# Altium schematic sheet DC_DC_5V_1.SchDoc
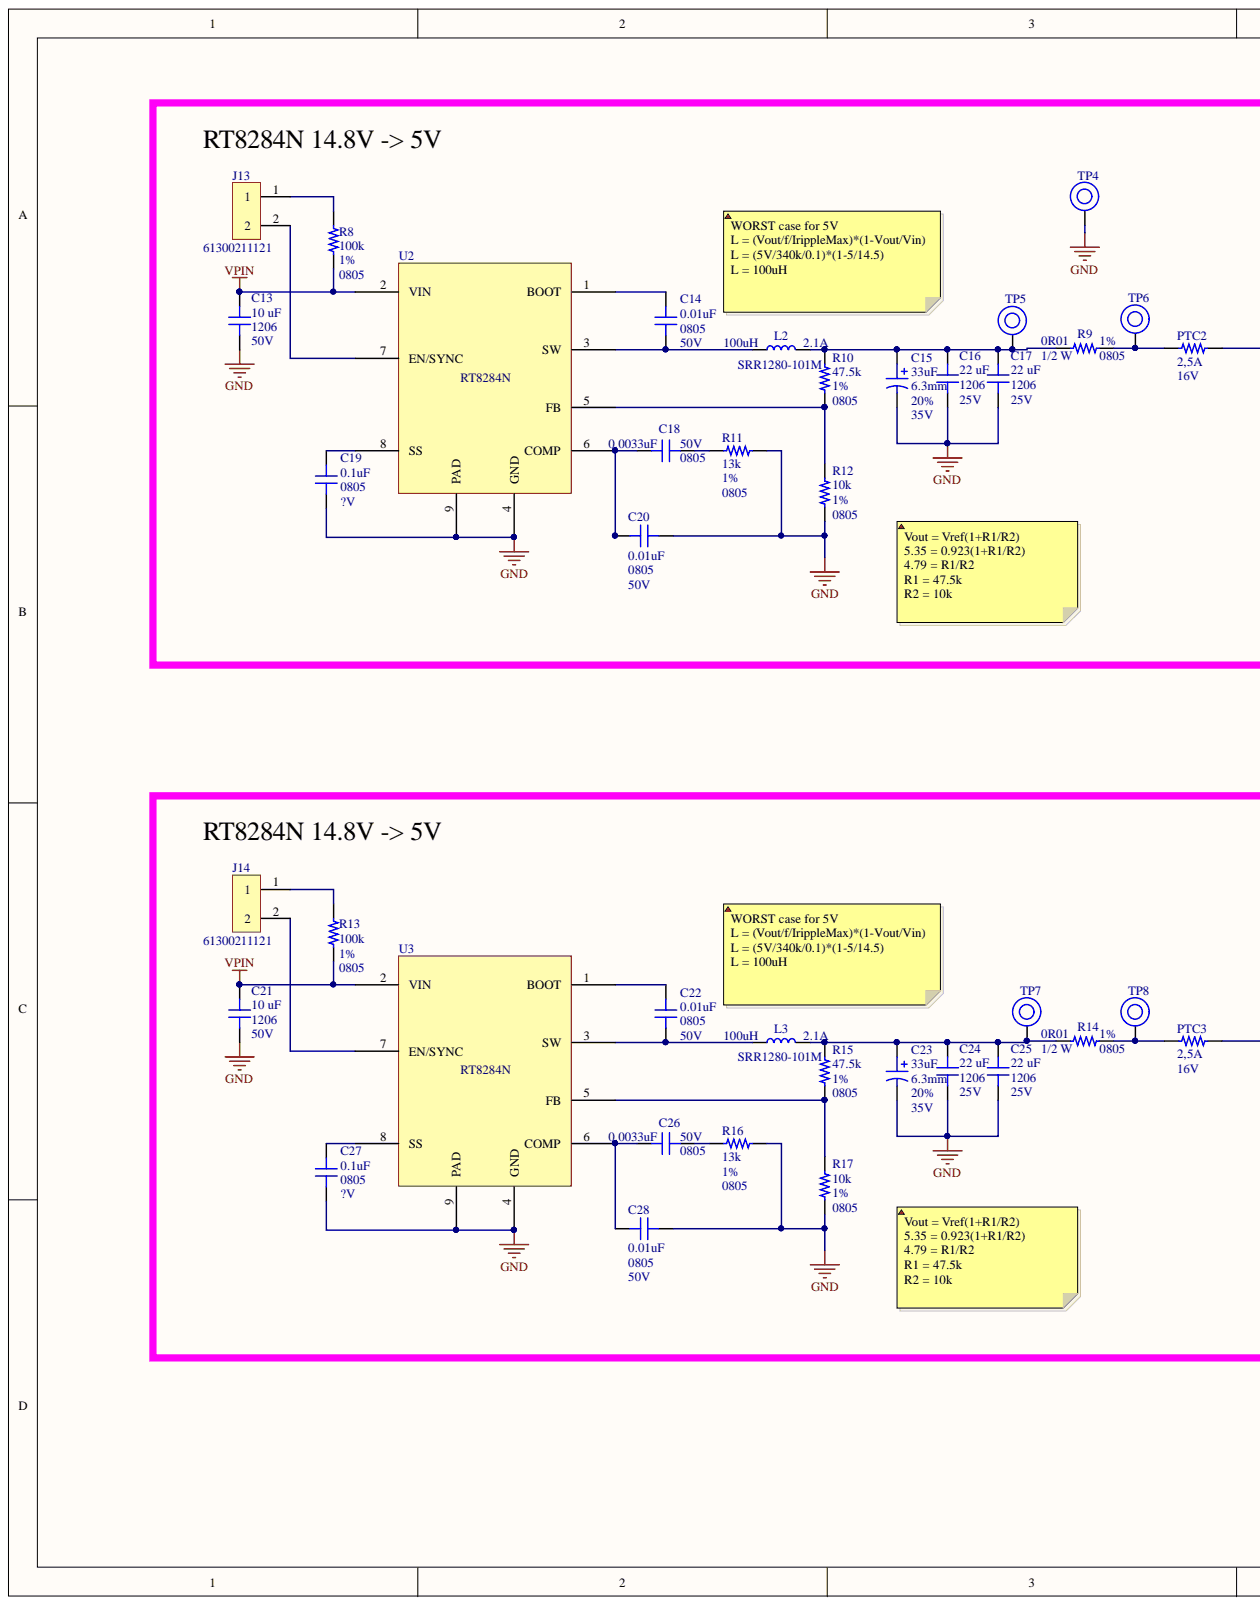
[diagram p0: DC_DC_5V_1.SchDoc, left]
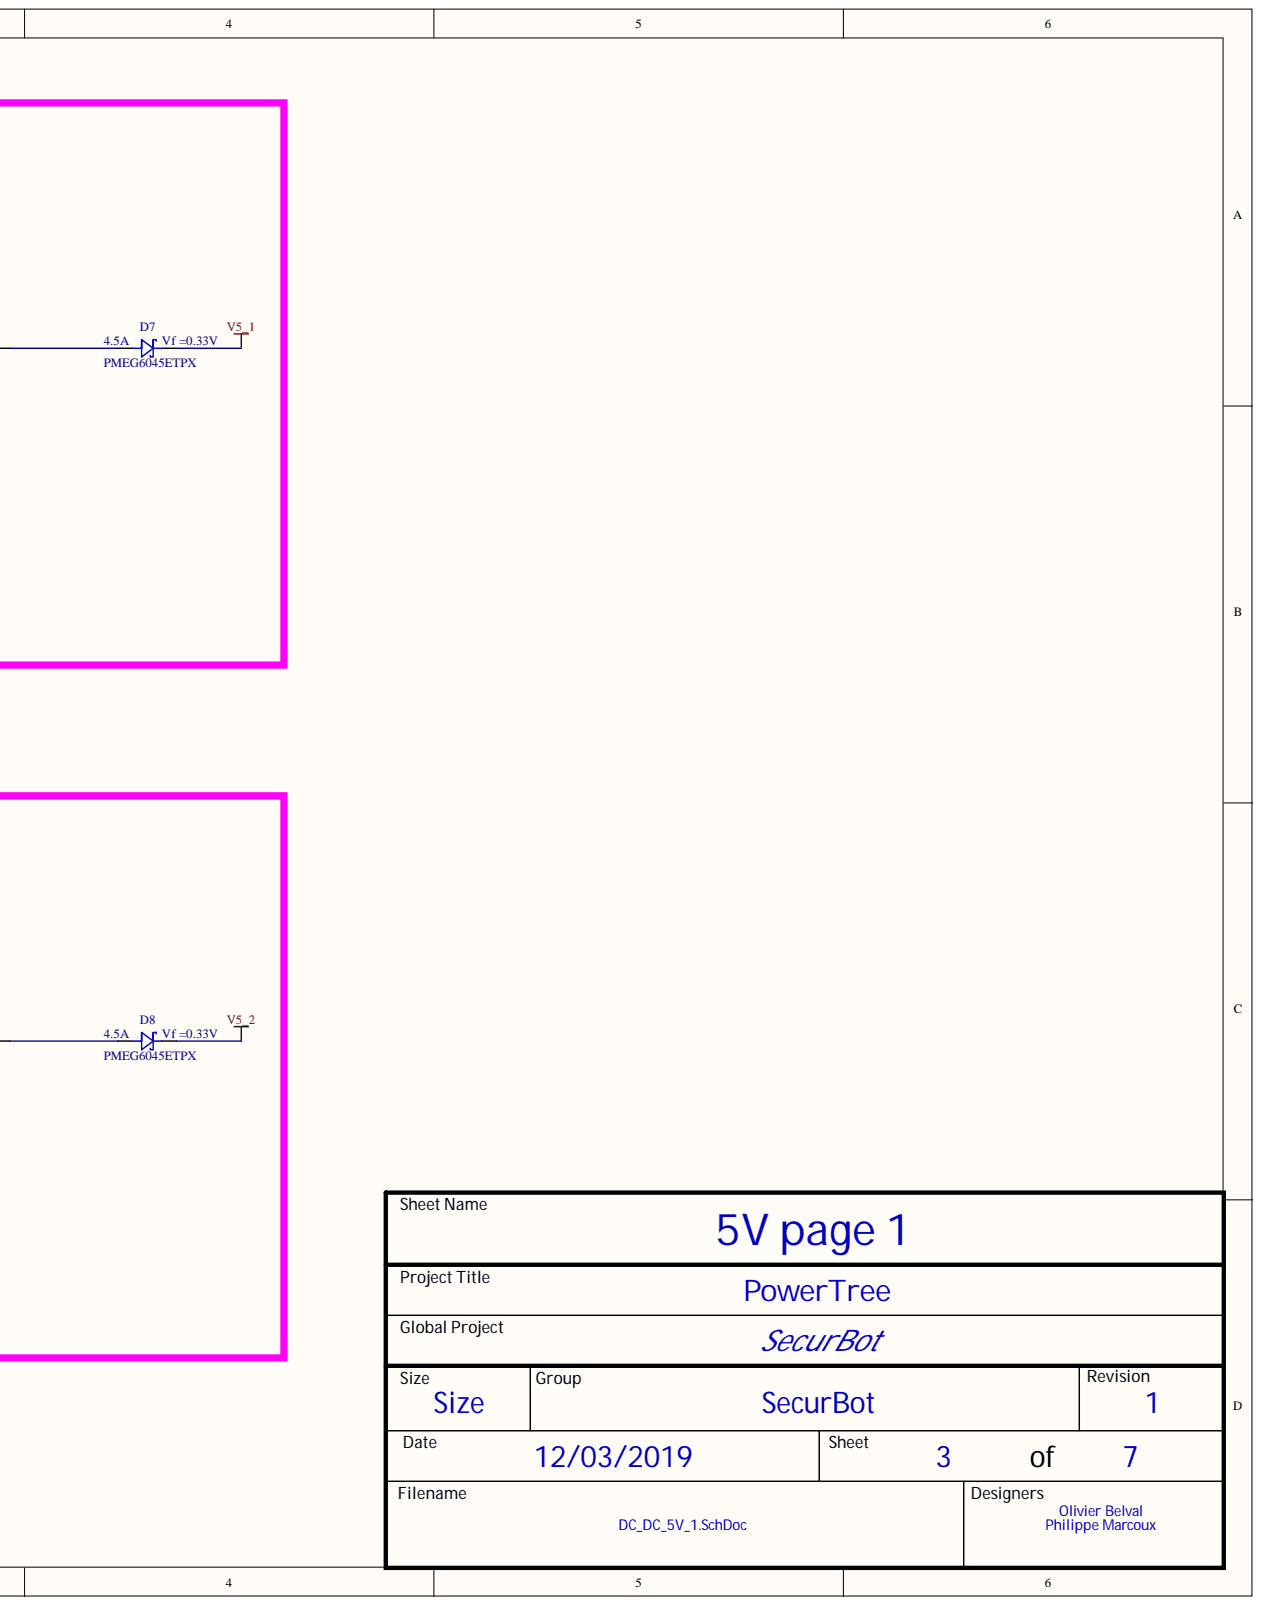
[diagram p1: DC_DC_5V_1.SchDoc, right]

## Parts
C13  value 10 uF  Package: 1206  Voltage: 50V  [CAP_1206]  (hidden comment: CAP - 1206)
C14  value 0.01uF  Package: 0805  Voltage: 50V  [CAP_0805]  (hidden comment: CAP - 0805)
C15  value 33uF  Package: 6.3mm  Tolerance: 20%  Voltage: 35V  [CAP ALU - 6.3mm DIA]  (hidden comment: CAP ALU - 6.3mm)
C16  value 22 uF  Package: 1206  Voltage: 25V  [CAP_1206]  (hidden comment: CAP - 1206)
C17  value 22 uF  Package: 1206  Voltage: 25V  [CAP_1206]  (hidden comment: CAP - 1206)
C18  value 0.0033uF  Package: 0805  Voltage: 50V  [CAP_0805]  (hidden comment: CAP - 0805)
C19  value 0.1uF  Package: 0805  Voltage: ?V  [CAP_0805]  (hidden comment: CAP - 0805)
C20  value 0.01uF  Package: 0805  Voltage: 50V  [CAP_0805]  (hidden comment: CAP - 0805)
C21  value 10 uF  Package: 1206  Voltage: 50V  [CAP_1206]  (hidden comment: CAP - 1206)
C22  value 0.01uF  Package: 0805  Voltage: 50V  [CAP_0805]  (hidden comment: CAP - 0805)
C23  value 33uF  Package: 6.3mm  Tolerance: 20%  Voltage: 35V  [CAP ALU - 6.3mm DIA]  (hidden comment: CAP ALU - 6.3mm)
C24  value 22 uF  Package: 1206  Voltage: 25V  [CAP_1206]  (hidden comment: CAP - 1206)
C25  value 22 uF  Package: 1206  Voltage: 25V  [CAP_1206]  (hidden comment: CAP - 1206)
C26  value 0.0033uF  Package: 0805  Voltage: 50V  [CAP_0805]  (hidden comment: CAP - 0805)
C27  value 0.1uF  Package: 0805  Voltage: ?V  [CAP_0805]  (hidden comment: CAP - 0805)
C28  value 0.01uF  Package: 0805  Voltage: 50V  [CAP_0805]  (hidden comment: CAP - 0805)
D7  Current: 4.5A  Part number: PMEG6045ETPX  Vf: Vf =0.33V  [DO-214AC_SOD128]  (hidden comment: SCHOTTKEY)
D8  Current: 4.5A  Part number: PMEG6045ETPX  Vf: Vf =0.33V  [DO-214AC_SOD128]  (hidden comment: SCHOTTKEY)
J13  Part number: 61300211121  [HDR1X2]  (hidden comment: Header 2 pin SM)
J14  Part number: 61300211121  [HDR1X2]  (hidden comment: Header 2 pin SM)
L2  value 100uH  Current: 2.1A  Part number: SRR1280-101M  [BOURNS 12.5x12.5mm]  (hidden comment: IND)
L3  value 100uH  Current: 2.1A  Part number: SRR1280-101M  [BOURNS 12.5x12.5mm]  (hidden comment: IND)
PTC2  Trip value: 2,5A  Voltage: 16V  [PTC - 1812]  (hidden comment: PTC - 1812)
PTC3  Trip value: 2,5A  Voltage: 16V  [PTC - 1812]  (hidden comment: PTC - 1812)
R8  value 100k  Package: 0805  Tolerance: 1%  [FER_0805]  (hidden comment: RES - 0805)
R9  value 0R01  Package: 0805  Tolerance: 1%  Watt: 1/2 W  [FER_0805]  (hidden comment: RES - 0805)
R10  value 47.5k  Package: 0805  Tolerance: 1%  [FER_0805]  (hidden comment: RES - 0805)
R11  value 13k  Package: 0805  Tolerance: 1%  [FER_0805]  (hidden comment: RES - 0805)
R12  value 10k  Package: 0805  Tolerance: 1%  [FER_0805]  (hidden comment: RES - 0805)
R13  value 100k  Package: 0805  Tolerance: 1%  [FER_0805]  (hidden comment: RES - 0805)
R14  value 0R01  Package: 0805  Tolerance: 1%  Watt: 1/2 W  [FER_0805]  (hidden comment: RES - 0805)
R15  value 47.5k  Package: 0805  Tolerance: 1%  [FER_0805]  (hidden comment: RES - 0805)
R16  value 13k  Package: 0805  Tolerance: 1%  [FER_0805]  (hidden comment: RES - 0805)
R17  value 10k  Package: 0805  Tolerance: 1%  [FER_0805]  (hidden comment: RES - 0805)
TP4  TestPoint 3x3mm  [TestPoint 3X3mm]  (value not drawn)
TP5  TestPoint 3x3mm  [TestPoint 3X3mm]  (value not drawn)
TP6  TestPoint 3x3mm  [TestPoint 3X3mm]  (value not drawn)
TP7  TestPoint 3x3mm  [TestPoint 3X3mm]  (value not drawn)
TP8  TestPoint 3x3mm  [TestPoint 3X3mm]  (value not drawn)
U2  RT8284N  [SOP8]
U3  RT8284N  [SOP8]

## Nets
GND: C13.2 C15.2 C16.2 C17.2 C19.2 C20.2 C21.2 C23.2 C24.2 C25.2 C27.2 C28.2 R11.2 R12.2 R16.2 R17.2 TP4.1 U2.4(GND) U2.9(PAD) U3.4(GND) U3.9(PAD)
V5_1: D7.2
V5_2: D8.2
VPIN: C13.1 C21.1 R8.1 R13.1 U2.2(VIN) U3.2(VIN)
NetC14_1: C14.1 U2.1(BOOT)
NetC14_2: C14.2 L2.1 U2.3(SW)
NetC15_1: C15.1 C16.1 C17.1 L2.2 R9.1 R10.1 TP5.1
NetC18_1: C18.1 C20.1 U2.6(COMP)
NetC18_2: C18.2 R11.1
NetC19_1: C19.1 U2.8(SS)
NetC22_1: C22.1 U3.1(BOOT)
NetC22_2: C22.2 L3.1 U3.3(SW)
NetC23_1: C23.1 C24.1 C25.1 L3.2 R14.1 R15.1 TP7.1
NetC26_1: C26.1 C28.1 U3.6(COMP)
NetC26_2: C26.2 R16.1
NetC27_1: C27.1 U3.8(SS)
NetD7_1: D7.1 PTC2.2
NetD8_1: D8.1 PTC3.2
NetJ13_1: J13.1 R8.2
NetJ13_2: J13.2 U2.7(EN/SYNC)
NetJ14_1: J14.1 R13.2
NetJ14_2: J14.2 U3.7(EN/SYNC)
NetPTC2_1: PTC2.1 R9.2 TP6.1
NetPTC3_1: PTC3.1 R14.2 TP8.1
NetR10_2: R10.2 R12.1 U2.5(FB)
NetR15_2: R15.2 R17.1 U3.5(FB)

## Library data of the parts
C13 C21 = CAP - 1206: Part number: GRT31CR61H106ME01L; Component Kind: Standard; Description: CAP - 1206; Library Name: SecureBot.SchLib
C14 C20 C22 C28 = CAP - 0805: Part number: CL21B103KBANNNC; Component Kind: Standard; Description: CAP - 0805; Library Name: SecureBot.SchLib
C15 C23 = CAP ALU - 6_3mm: Part number: EEE-FK1V330P; Component Kind: Standard; Description: CAP ALU - 6.3mm; Library Name: SecureBot.SchLib
C16 C17 C24 C25 = CAP - 1206: Part number: CL31A226KAHNNNE; Component Kind: Standard; Description: CAP - 1206; Library Name: SecureBot.SchLib
C18 C26 = CAP - 0805: Part number: CL21B332KBANNNC; Component Kind: Standard; Description: CAP - 0805; Library Name: SecureBot.SchLib
C19 C27 = CAP - 0805: Part number: CC0805KRX7R9BB104; Component Kind: Standard; Description: CAP - 0805; Library Name: SecureBot.SchLib
PTC2 PTC3 = PTC - 1812: Part number: 0ZCG0160BF2C; Component Kind: Standard; Description: PTC - 1812; Package: 1812; Res: ?; Library Name: SecureBot.SchLib
R8 R13 = RES - 0805: Part number: RMCF0805FT100K; Watt: ? W; Component Kind: Standard; Description: RES - 0805; Library Name: SecureBot.SchLib
R9 R14 = RES - 0805: Part number: KRL1220E-M-R010-F-T5; Component Kind: Standard; Description: RES - 0805; Library Name: SecureBot.SchLib
R10 R15 = RES - 0805: Part number: RMCF0805FT47K5; Watt: ? W; Component Kind: Standard; Description: RES - 0805; Library Name: SecureBot.SchLib
R11 R16 = RES - 0805: Part number: RC0805FR-0713KL; Watt: ? W; Component Kind: Standard; Description: RES - 0805; Library Name: SecureBot.SchLib
R12 R17 = RES - 0805: Part number: RMCF0805FT10K0; Watt: ? W; Component Kind: Standard; Description: RES - 0805; Library Name: SecureBot.SchLib
D7 D8 = SCHOTTKEY: Component Kind: Standard; Library Name: SecureBot.SchLib
J13 J14 = Header 2 pin SM: Component Kind: Standard; Library Name: SecureBot.SchLib
L2 L3 = IND: Component Kind: Standard; Description: IND; Package: ?; Library Name: SecureBot.SchLib
TP4-TP8 = TestPoint 3x3mm: Component Kind: Standard; Library Name: SecureBot.SchLib
U2 U3 = RT8284N: Component Kind: Standard; Description: RT8284N; Part number: RT8284N; Library Name: SecureBot.SchLib
